# Altium schematic sheet 7.AUDIO-TFCARD.SchDoc(7.AUDIO-TFCARD)
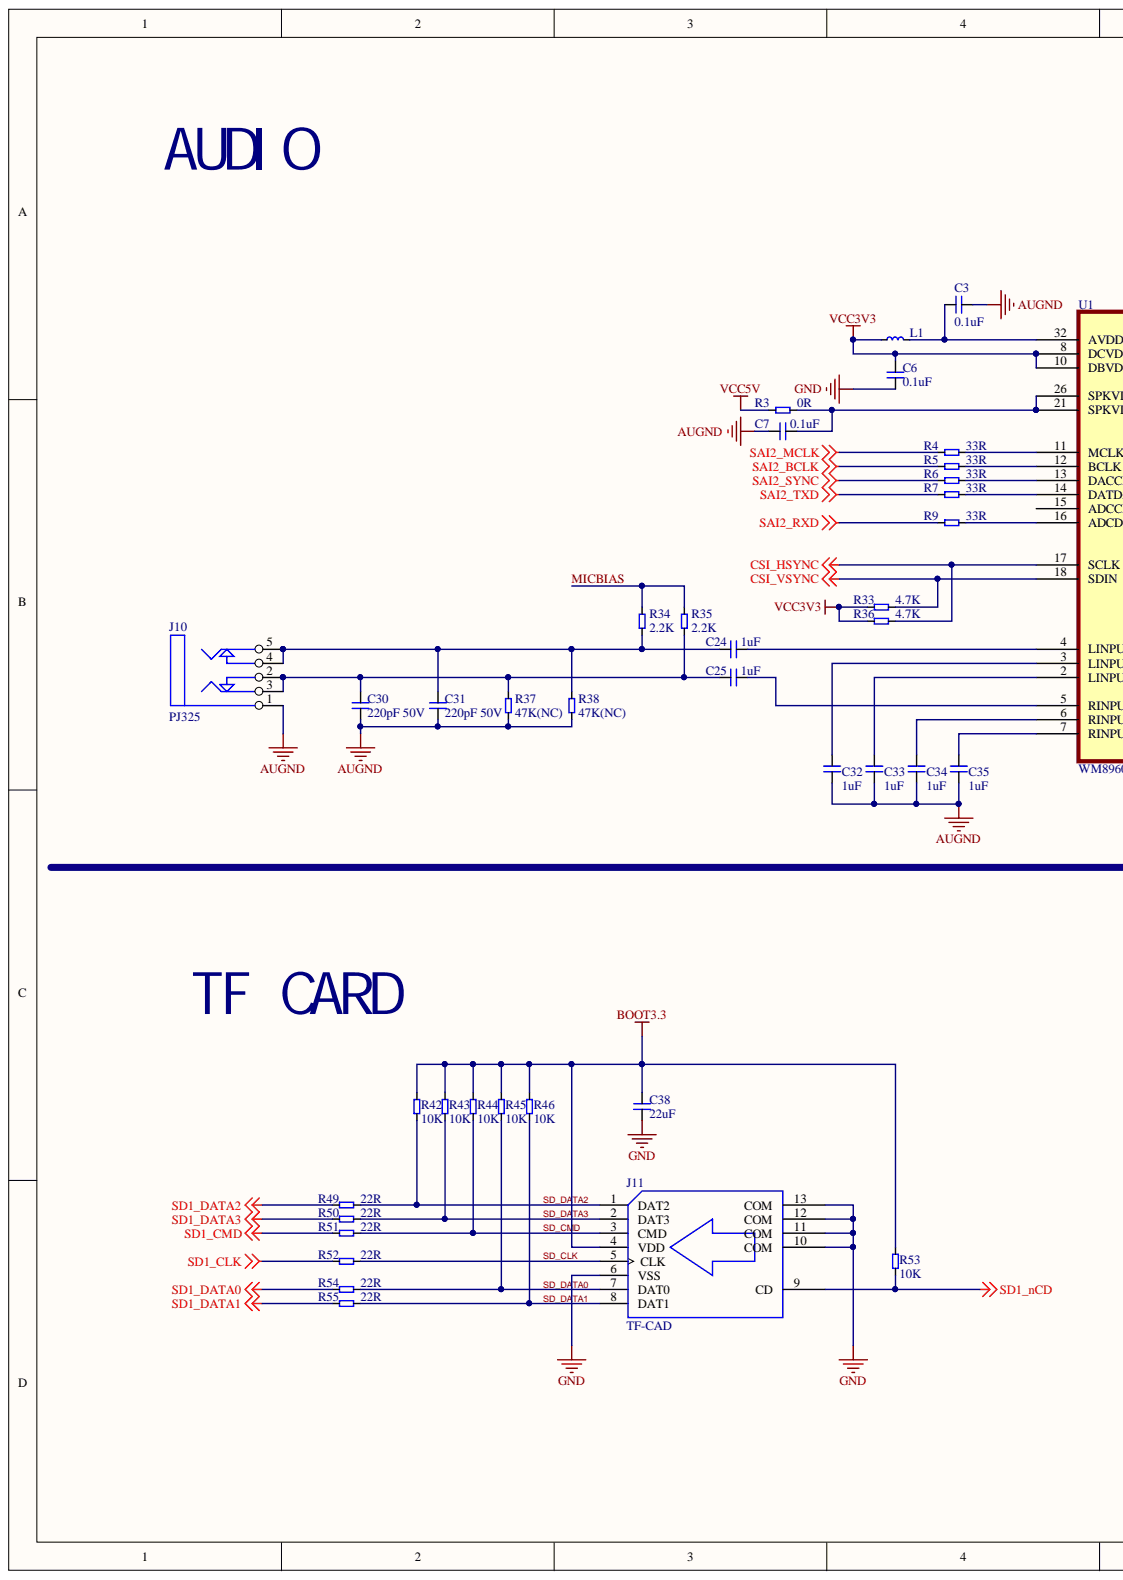
[diagram p0: 7.AUDIO-TFCARD.SchDoc, left]
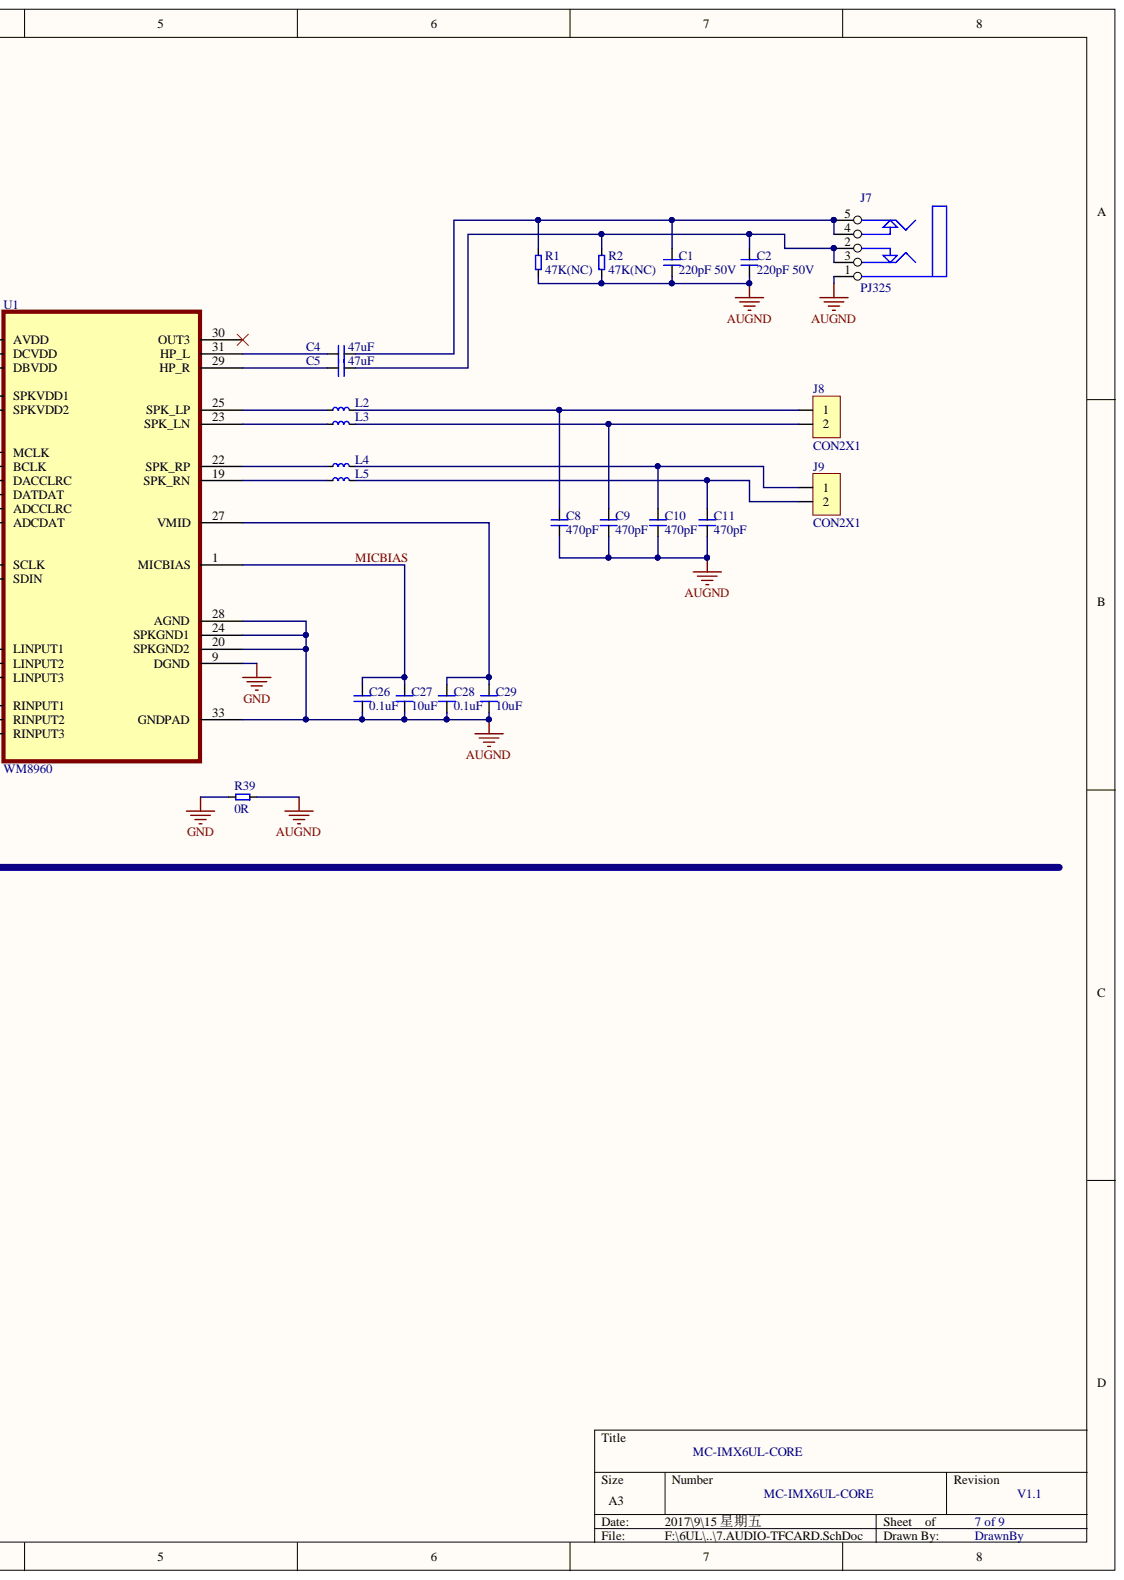
[diagram p1: 7.AUDIO-TFCARD.SchDoc, right]

## Parts
C1  220pF 50V  [0603C]
C2  220pF 50V  [0603C]
C3  0.1uF  [0603C]
C4  47uF  [1206C]
C5  47uF  [1206C]
C6  0.1uF  [0603C]
C7  0.1uF  [0603C]
C8  470pF  [0603C]
C9  470pF  [0603C]
C10  470pF  [0603C]
C11  470pF  [0603C]
C24  1uF  [0603C]
C25  1uF  [0603C]
C26  0.1uF  [0603C]
C27  10uF  [0805C]
C28  0.1uF  [0603C]
C29  10uF  [0805C]
C30  220pF 50V  [0603C]
C31  220pF 50V  [0603C]
C32  1uF  [0603C]
C33  1uF  [0603C]
C34  1uF  [0603C]
C35  1uF  [0603C]
C38  22uF  [0805C]
J7  PJ325  [PJ325]
J8  CON2X1  [IDC2X1-2.54MM-W]
J9  CON2X1  [IDC2X1-2.54MM-W]
J10  PJ325  [PJ325]
J11  TF-CAD  [TF-CARD]
L1  电感  [0603L]  (value not drawn)
L2  电感  [0603L]  (value not drawn)
L3  电感  [0603L]  (value not drawn)
L4  电感  [0603L]  (value not drawn)
L5  电感  [0603L]  (value not drawn)
R1  47K(NC)  [0603R]
R2  47K(NC)  [0603R]
R3  0R  [0603R]
R4  33R  [0603R]
R5  33R  [0603R]
R6  33R  [0603R]
R7  33R  [0603R]
R9  33R  [0603R]
R33  4.7K  [0603R]
R34  2.2K  [0603R]
R35  2.2K  [0603R]
R36  4.7K  [0603R]
R37  47K(NC)  [0603R]
R38  47K(NC)  [0603R]
R39  0R  [0603R]
R42  10K  [0603R]
R43  10K  [0603R]
R44  10K  [0603R]
R45  10K  [0603R]
R46  10K  [0603R]
R49  22R  [0603R]
R50  22R  [0603R]
R51  22R  [0603R]
R52  22R  [0603R]
R53  10K  [0603R]
R54  22R  [0603R]
R55  22R  [0603R]
U1  WM8960  [QFN-32]

## Nets
AUGND: C1.1 C2.1 C3.2 C7.1 C8.1 C9.1 C10.1 C11.1 C26.2 C27.2 C28.2 C29.2 C30.1 C31.1 C32.1 C33.1 C34.1 C35.1 J7.1 J10.1 R1.2 R2.2 R37.2 R38.2 R39.1 U1.20(SPKGND2) U1.24(SPKGND1) U1.28(AGND) U1.33(GNDPAD)
BOOT3.3: C38.2 J11.4(VDD) R42.2 R43.2 R44.2 R45.2 R46.2 R53.2
CSI_HSYNC: R36.2 U1.17(SCLK)
CSI_VSYNC: R33.2 U1.18(SDIN)
GND: C6.2 C38.1 J11.6(VSS) J11.10(COM) J11.11(COM) J11.12(COM) J11.13(COM) R39.2 U1.9(DGND)
SAI2_BCLK: R5.1
SAI2_MCLK: R4.1
SAI2_RXD: R9.1
SAI2_SYNC: R6.1
SAI2_TXD: R7.1
SD1_CLK: R52.1
SD1_CMD: R51.1
SD1_DATA0: R54.1
SD1_DATA1: R55.1
SD1_DATA2: R49.1
SD1_DATA3: R50.1
SD1_NCD: J11.9(CD) R53.1
VCC3V3: C6.1 L1.1 R33.1 R36.1 U1.8(DCVDD) U1.10(DBVDD)
VCC5V: R3.1
MICBIAS: C26.1 C27.1 R34.2 R35.2 U1.1(MICBIAS)
SD_CLK: J11.5(CLK) R52.2
SD_CMD: J11.3(CMD) R44.1 R51.2
SD_DATA0: J11.7(DAT0) R45.1 R54.2
SD_DATA1: J11.8(DAT1) R46.1 R55.2
SD_DATA2: J11.1(DAT2) R42.1 R49.2
SD_DATA3: J11.2(DAT3) R43.1 R50.2
NetC1_2: C1.2 C4.2 J7.4 J7.5 R1.1
NetC2_2: C2.2 C5.2 J7.2 J7.3 R2.1
NetC3_1: C3.1 L1.2 U1.32(AVDD)
NetC4_1: C4.1 U1.31(HP_L)
NetC5_1: C5.1 U1.29(HP_R)
NetC7_2: C7.2 R3.2 U1.21(SPKVDD2) U1.26(SPKVDD1)
NetC8_2: C8.2 J8.1 L2.2
NetC9_2: C9.2 J8.2 L3.2
NetC10_2: C10.2 J9.1 L4.2
NetC11_2: C11.2 J9.2 L5.2
NetC24_1: C24.1 C31.2 J10.4 J10.5 R34.1 R38.1
NetC24_2: C24.2 U1.4(LINPUT1)
NetC25_1: C25.1 C30.2 J10.2 J10.3 R35.1 R37.1
NetC25_2: C25.2 U1.5(RINPUT1)
NetC28_1: C28.1 C29.1 U1.27(VMID)
NetC32_2: C32.2 U1.3(LINPUT2)
NetC33_2: C33.2 U1.2(LINPUT3)
NetC34_2: C34.2 U1.6(RINPUT2)
NetC35_2: C35.2 U1.7(RINPUT3)
NetL2_1: L2.1 U1.25(SPK_LP)
NetL3_1: L3.1 U1.23(SPK_LN)
NetL4_1: L4.1 U1.22(SPK_RP)
NetL5_1: L5.1 U1.19(SPK_RN)
NetR4_2: R4.2 U1.11(MCLK)
NetR5_2: R5.2 U1.12(BCLK)
NetR6_2: R6.2 U1.13(DACCLRC)
NetR7_2: R7.2 U1.14(DATDAT)
NetR9_2: R9.2 U1.16(ADCDAT)

Not connected: U1 15(ADCCLRC) 30(OUT3)

## Library data of the parts
C1-C11 C24-C35 C38 = 电容: Component Kind: Standard; Description: 电容
  C3-C7 C24-C29 C32-C35: Library Name: LDT-SCH.SCHLIB
R1-R7 R9 R33-R39 R42-R46 R49-R55 = 电阻: Component Kind: Standard; Description: 电阻; Library Name: LDT-SCH.SCHLIB
J7 J10 = PJ325: Component Kind: Standard; Description: PJ325; Library Name: LDT-SCH.SCHLIB
J8 J9 = CON2X1: Component Kind: Standard; Library Name: LDT-SCH.SCHLIB
J11 = TF-CAD: Component Kind: Standard; Library Name: LDT-SCH.SCHLIB
L1-L5 = 电感: Component Kind: Standard; Description: 电感; Library Name: LDT-SCH.SCHLIB
U1 = WM8960: Component Kind: Standard; Description: WM8960; Library Name: LDT-SCH.SCHLIB
